# Altium schematic sheet Bord-Con.SchDoc(Bord-Con)
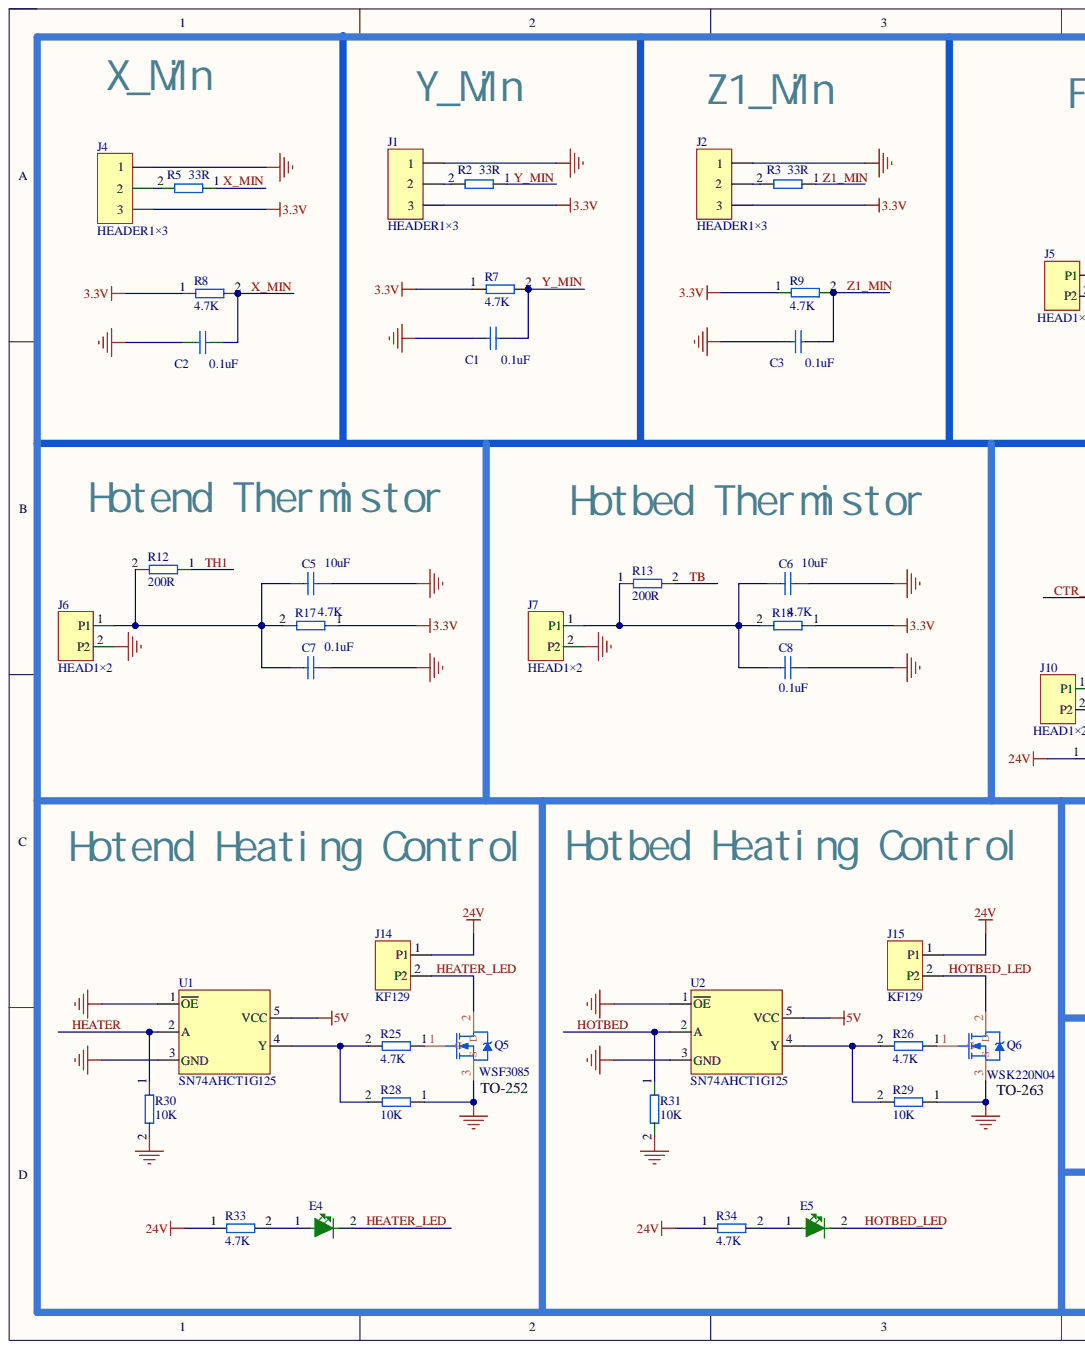
[diagram p0: Bord-Con.SchDoc, left]
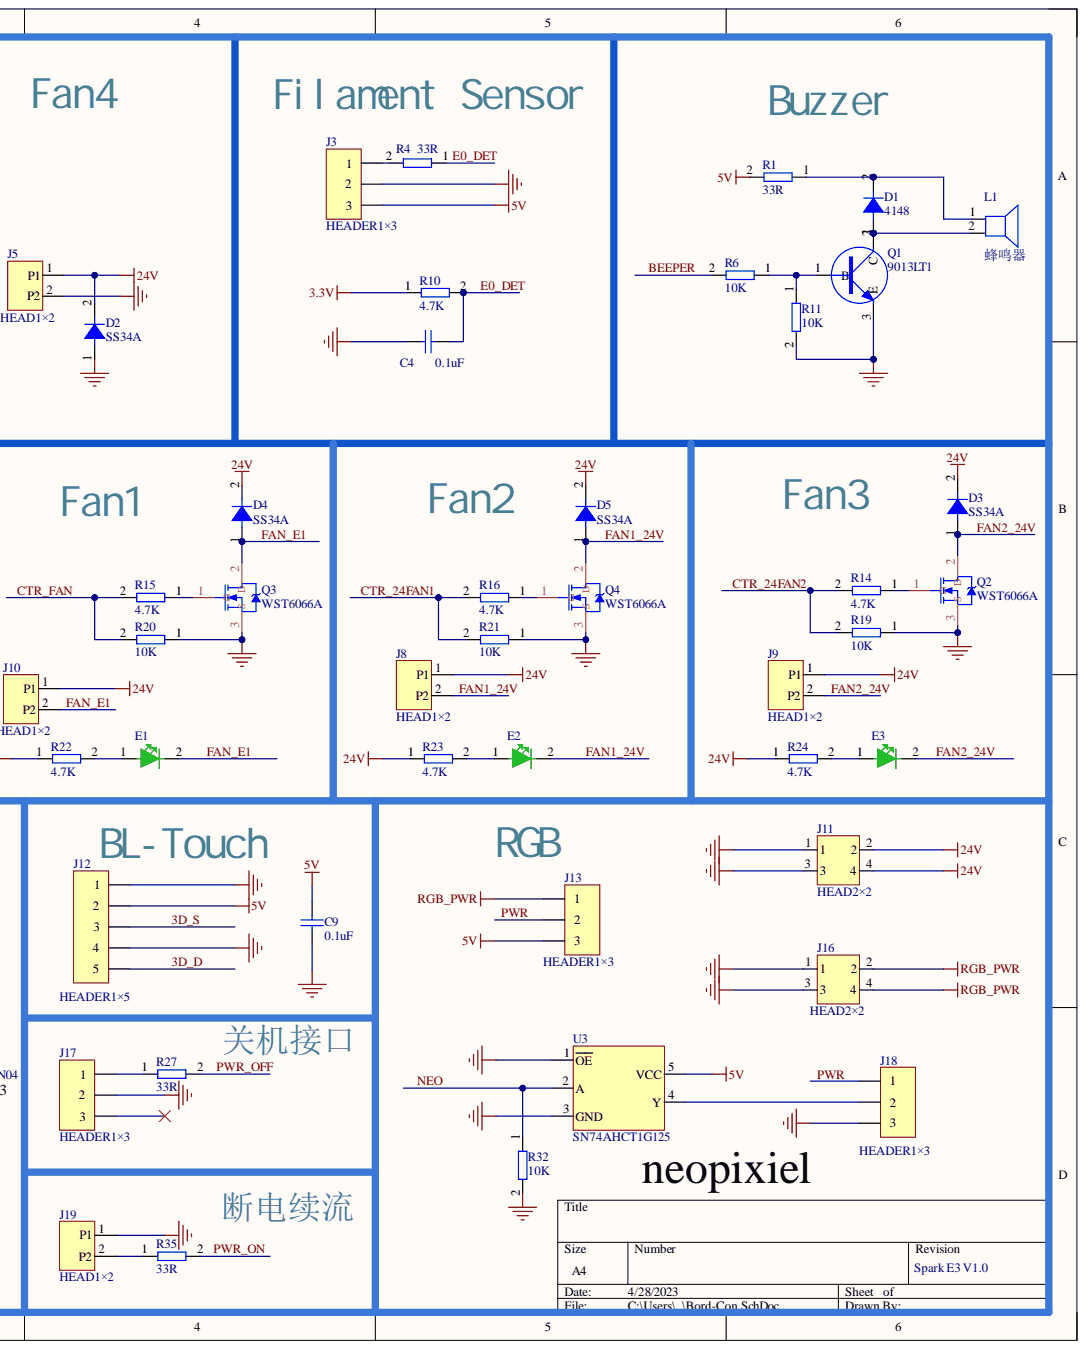
[diagram p1: Bord-Con.SchDoc, right]

## Parts
C1  0.1uF  [C0603]
C2  0.1uF  [C0603]
C3  0.1uF  [C0603]
C4  0.1uF  [C0603]
C5  10uF  [C0805]
C6  10uF  [C0805]
C7  0.1uF  [C0603]
C8  0.1uF  [C0603]
C9  0.1uF  [C0603]
D1  4148  [SOD-123]
D2  SS34A  [SS34]
D3  SS34A  [SS34]
D4  SS34A  [SS34]
D5  SS34A  [SS34]
E1  LED绿灯  [0603 - LED-B]  (value not drawn)
E2  LED绿灯  [0603 - LED-B]  (value not drawn)
E3  LED绿灯  [0603 - LED-B]  (value not drawn)
E4  LED绿灯  [0603 - LED-B]  (value not drawn)
E5  LED绿灯  [0603 - LED-B]  (value not drawn)
J1  HEADER1×3  [CN/2.54/3]
J2  HEADER1×3  [CN/2.54/3]
J3  HEADER1×3  [CN/2.54/3]
J4  HEADER1×3  [CN/2.54/3]
J5  HEAD1×2  [CN/2.54/2]
J6  HEAD1×2  [CN/2.54/2]
J7  HEAD1×2  [CN/2.54/2]
J8  HEAD1×2  [CN/2.54/2]
J9  HEAD1×2  [CN/2.54/2]
J10  HEAD1×2  [CN/2.54/2]
J11  HEAD2×2  [双排针/2.54/2]
J12  HEADER1×5  [DIP/2.54/5P]
J13  HEADER1×3  [DIP/2.54/3P]
J14  KF129  [KF129-2P]
J15  KF129  [KF129-2P]
J16  HEAD2×2  [双排针/2.54/2]
J17  HEADER1×3  [CN/2.54/3]
J18  HEADER1×3  [CN/2.54/3]
J19  HEAD1×2  [CN/2.54/2]
L1  蜂鸣器  [FMQ/5/9]
Q1  9013LT1  [SMT-NPN]
Q2  WST6066A  [A03400/N沟道mos管]
Q3  WST6066A  [A03400/N沟道mos管]
Q4  WST6066A  [A03400/N沟道mos管]
Q5  WSF3085  [TO-252]
Q6  WSK220N04  [TO-263-2]
R1  33R  [R0603]
R2  33R  [R0603]
R3  33R  [R0603]
R4  33R  [R0603]
R5  33R  [R0603]
R6  10K  [R0603]
R7  4.7K  [R0603]
R8  4.7K  [R0603]
R9  4.7K  [R0603]
R10  4.7K  [R0603]
R11  10K  [R0603]
R12  200R  [R0603]
R13  200R  [R0603]
R14  4.7K  [R0603]
R15  4.7K  [R0603]
R16  4.7K  [R0603]
R17  4.7K  [R0603]
R18  4.7K  [R0603]
R19  10K  [R0603]
R20  10K  [R0603]
R21  10K  [R0603]
R22  4.7K  [R0603]
R23  4.7K  [R0603]
R24  4.7K  [R0603]
R25  4.7K  [R0603]
R26  4.7K  [R0603]
R27  33R  [R0603]
R28  10K  [R0603]
R29  10K  [R0603]
R30  10K  [R0603]
R31  10K  [R0603]
R32  10K  [R0603]
R33  4.7K  [R0603]
R34  4.7K  [R0603]
R35  33R  [R0603]
U1  SN74AHCT1G125  [SOT23-5]
U2  SN74AHCT1G125  [SOT23-5]
U3  SN74AHCT1G125  [SOT23-5]

## Nets
3.3V: J1.3 J2.3 J4.3 R7.1 R8.1 R9.1 R10.1 R17.1 R18.1
5V: C9.2 J3.3 J12.2 J13.3 R1.2 U1.5(VCC) U2.5(VCC) U3.5(VCC)
24V: D2.2 D3.2 D4.2 D5.2 J5.1 J8.1 J9.1 J10.1 J11.2 J11.4 J14.1 J15.1 R22.1 R23.1 R24.1 R33.1 R34.1
GND: C1.1 C2.1 C3.1 C4.1 C5.2 C6.2 C7.2 C8.2 C9.1 D2.1 J1.1 J2.1 J3.2 J4.1 J5.2 J6.2 J7.2 J11.1 J11.3 J12.1 J12.4 J16.1 J16.3 J17.2 J18.3 J19.1 Q1.3 Q2.3 Q3.3 Q4.3 Q5.3 Q6.3 R11.2 R19.1 R20.1 R21.1 R28.1 R29.1 R30.2 R31.2 R32.2 U1.1(OE) U1.3(GND) U2.1(OE) U2.3(GND) U3.1(OE) U3.3(GND)
RGB_PWR: J13.1 J16.2 J16.4
3D_D: J12.5
3D_S: J12.3
BEEPER: R6.2
CTR_24FAN1: R16.2 R21.2
CTR_24FAN2: R14.2 R19.2
CTR_FAN: R15.2 R20.2
E0_DET: C4.2 R4.1 R10.2
FAN1_24V: D5.1 E2.2 J8.2 Q4.2
FAN2_24V: D3.1 E3.2 J9.2 Q2.2
FAN_E1: D4.1 E1.2 J10.2 Q3.2
HEATER: R30.1 U1.2(A)
HEATER_LED: E4.2 J14.2 Q5.2
HOTBED: R31.1 U2.2(A)
HOTBED_LED: E5.2 J15.2 Q6.2
NEO: R32.1 U3.2(A)
PWR: J13.2 J18.1
PWR_OFF: R27.2
PWR_ON: R35.2
TB: R13.2
TH1: R12.1
X_MIN: C2.2 R5.1 R8.2
Y_MIN: C1.2 R2.1 R7.2
Z1_MIN: C3.2 R3.1 R9.2
NetC5_1: C5.1 C7.1 J6.1 R12.2 R17.2
NetC6_1: C6.1 C8.1 J7.1 R13.1 R18.2
NetD1_1: D1.1 L1.2 Q1.2
NetD1_2: D1.2 L1.1 R1.1
NetE1_1: E1.1 R22.2
NetE2_1: E2.1 R23.2
NetE3_1: E3.1 R24.2
NetE4_1: E4.1 R33.2
NetE5_1: E5.1 R34.2
NetJ1_2: J1.2 R2.2
NetJ2_2: J2.2 R3.2
NetJ3_1: J3.1 R4.2
NetJ4_2: J4.2 R5.2
NetJ17_1: J17.1 R27.1
NetJ18_2: J18.2 U3.4(Y)
NetJ19_2: J19.2 R35.1
NetQ1_1: Q1.1 R6.1 R11.1
NetQ2_1: Q2.1 R14.1
NetQ3_1: Q3.1 R15.1
NetQ4_1: Q4.1 R16.1
NetQ5_1: Q5.1 R25.1
NetQ6_1: Q6.1 R26.1
NetR25_2: R25.2 R28.2 U1.4(Y)
NetR26_2: R26.2 R29.2 U2.4(Y)

Not connected: J17 3

## Library data of the parts
C1-C9 = 电容: Component Kind: Standard; LatestRevisionDate: 17-Jul-2002; LatestRevisionNote: Re-released for DXP Platform.; PackageDescription: Radial Cap, Thru-Hole; 2 Leads; 0.3 in Pin Spacing; PackageReference: RAD-0.3; Published: 8-Jun-2000; Signal Integrity: Cap; Simulation: CAP; Value: ?; Library Name: MYschlib.SchLib; Publisher: Altium Limited
D1 = 4007: VALUE: 1N4007; Component Kind: Standard
D2-D5 = 肖特基/SS34: VALUE: SS34; Component Kind: Standard; Library Name: MYschlib.SchLib
J11 J16 = Component_1: Component Kind: Standard; Library Name: Schlib1.SchLib
J12 = HEADER1×3: Component Kind: Standard; Library Name: jietai-ADLB.SchLib
J14 J15 = HEAD1×2: Component Kind: Standard; Library Name: jietai-ADLB.SchLib
Q1 = 三极管/NPN/9014: Component Kind: Standard; Library Name: MYschlib.SchLib
Q2-Q4 = NMOS-2: Note: Pin 2 is electrically connected to pin 4.; Component Kind: Standard; ComponentLink1Description: Manufacturer Link; ComponentLink1URL: http://www.irf.com/; DatasheetVersion: PD-9.814; LatestRevisionDate: 17-Jul-2002; LatestRevisionNote: Re-released for DXP Platform.; PackageDescription: TO, Thru-Hole, Vertical, Heatsink Mounted; 3 In-Line Leads; Pitch 2.54 mm; PackageReference: TO-220AB; PackageVersion: Feb-1997; Published: 8-Jun-2000; Simulation: IRF1010; Library Name: MYschlib.SchLib; Publisher: Altium Limited
Q5 Q6 = NMOS-2: AO3400A; Note: Pin 2 is electrically connected to pin 4.; Component Kind: Standard; ComponentLink1Description: Manufacturer Link; ComponentLink1URL: http://www.irf.com/; DatasheetVersion: PD-9.814; LatestRevisionDate: 17-Jul-2002; LatestRevisionNote: Re-released for DXP Platform.; PackageDescription: TO, Thru-Hole, Vertical, Heatsink Mounted; 3 In-Line Leads; Pitch 2.54 mm; PackageReference: TO-220AB; PackageVersion: Feb-1997; Published: 8-Jun-2000; Simulation: IRF1010; Library Name: MYschlib.SchLib; Publisher: Altium Limited
R1-R35 = 电阻: Component Kind: Standard; value: ?; Library Name: MYschlib.SchLib
E1-E5 = LED绿灯: Component Kind: Standard; Library Name: MYschlib.SchLib
J1-J4 J13 J17 J18 = HEADER1×3: Component Kind: Standard; Library Name: jietai-ADLB.SchLib
J5-J10 J19 = HEAD1×2: Component Kind: Standard; Library Name: jietai-ADLB.SchLib
L1 = 蜂鸣器: Component Kind: Standard; Library Name: MYschlib.SchLib
U1-U3 = SN74AHCT1G125: Component Kind: Standard; Library Name: jietai-ADLB.SchLib
